# Altium schematic sheet SDCard.SchDoc(SDCard)
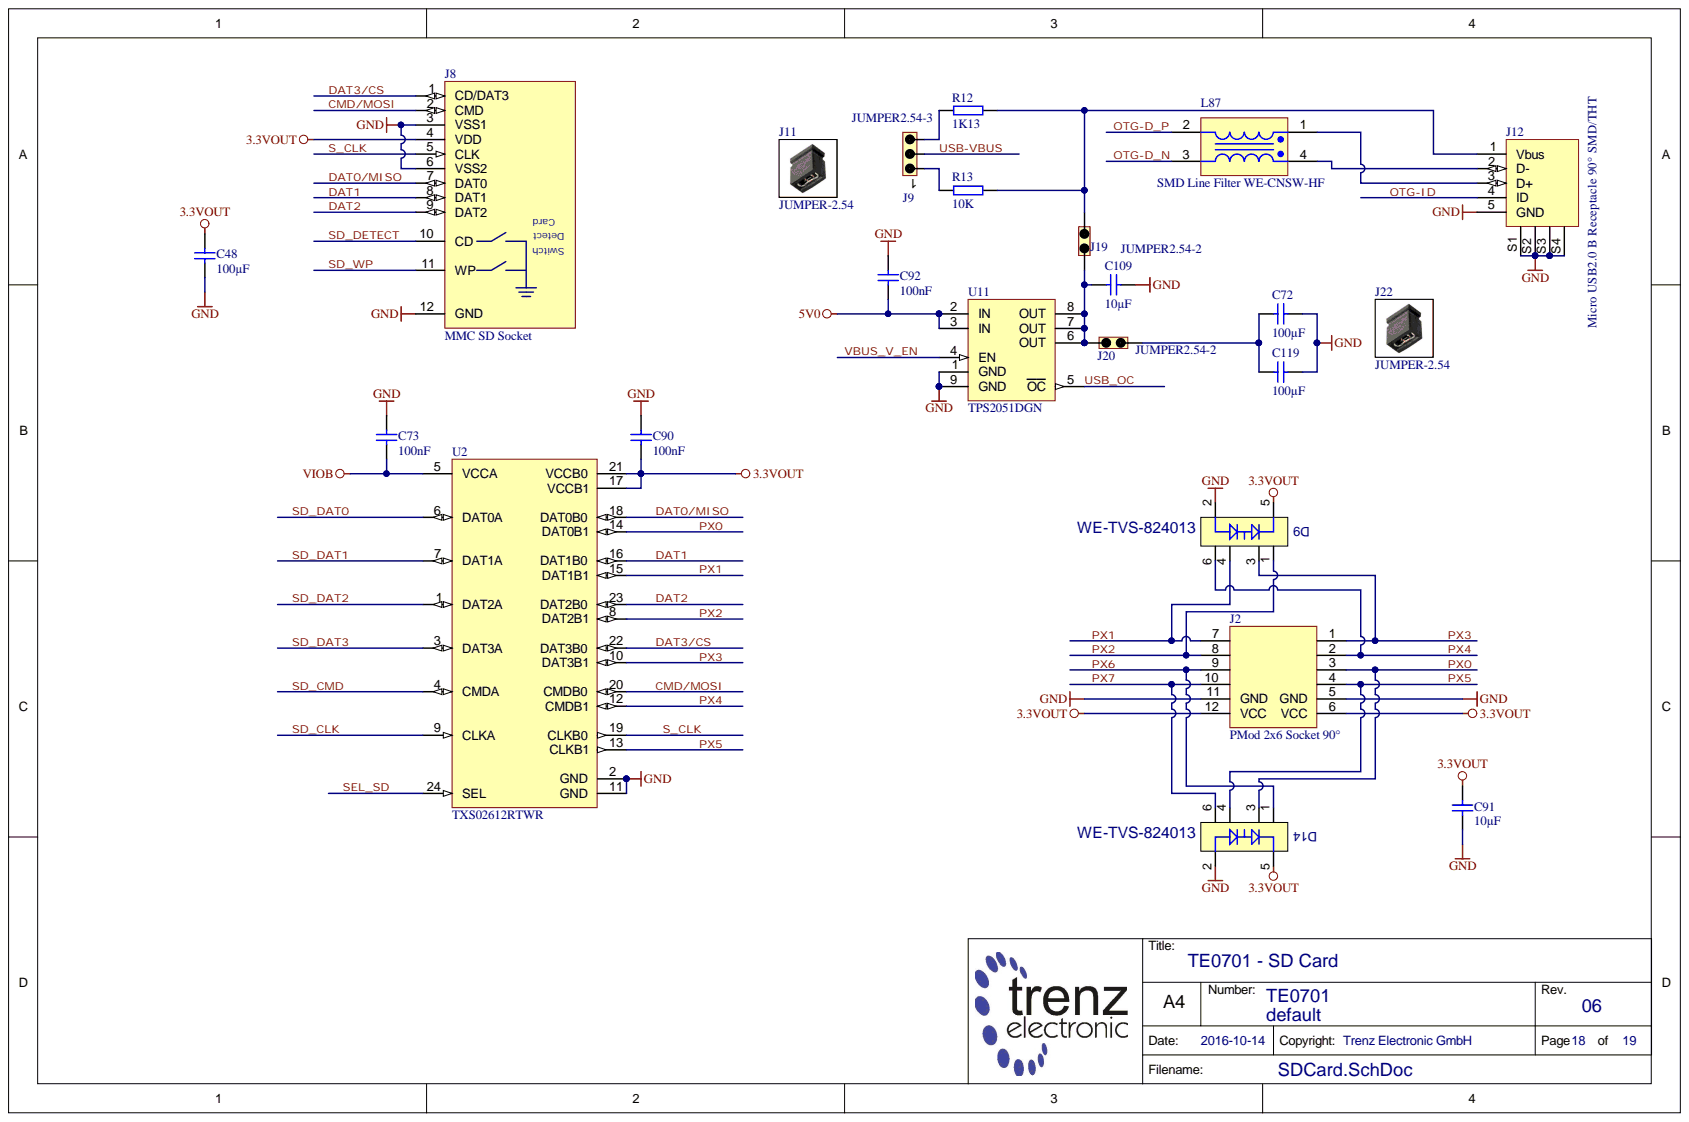
[diagram p0: SDCard.SchDoc]

## Parts
C48  100µF  [CAPC3216X180N]
C72  100µF  [CAPC3216X180N]
C73  100nF  [CAPC1005X55N]
C90  100nF  [CAPC1005X55N]
C91  10µF  [CAPC2012X135N]
C92  100nF  [CAPC1005X55N]
C109  10µF  [CAPC2012X135N]
C119  100µF  [CAPC3216X180N]
D9  WE-TVS-824013  [SOT95P280X145-6N]
D14  WE-TVS-824013  [SOT95P280X145-6N]
J2  PMod 2x6 Socket 90°  [PMod2x6]
J8  MMC SD Socket  Case: MMC SD  [104C-TAA0-R]
J9  JUMPER2.54-3  [JUMPER2_54-3]
J11  JUMPER-2.54  (mechanical)
J12  Micro USB2.0 B Receptacle 90° SMD/THT  [629105150521]
J19  JUMPER2.54-2  [JUMPER2_54-2]
J20  JUMPER2.54-2  [JUMPER2_54-2]
J22  JUMPER-2.54  (mechanical)
L87  SMD Line Filter WE-CNSW-HF  [WE-CNSW-HF]
R12  1K13  [RESC1005X40N]
R13  10K  [RESC1005X40N]
U2  TXS02612RTWR  [QFN50P400X400X80-25N-255]
U11  TPS2051DGN  [SOP65P488X107-9N-TI]

## Nets
3.3VOUT: C48.2 C90.1 C91.1 D9.5 D14.5 J2.6(VCC) J2.12(VCC) J8.4(VDD) U2.17(VCCB1) U2.21(VCCB0)
5V0: C92.1 U11.2(IN) U11.3(IN)
GND: C48.1 C72.1 C73.2 C90.2 C91.2 C92.2 C109.1 C119.2 D9.2 D14.2 J2.5(GND) J2.11(GND) J8.3(VSS1) J8.6(VSS2) J8.12(GND) J12.5(GND) J12.S1 J12.S2 J12.S3 J12.S4 U2.2(GND) U2.11(GND) U11.1(GND) U11.9(GND)
VIOB: C73.1 U2.5(VCCA)
CMD/MOSI: J8.2(CMD) U2.20(CMDB0)
DAT0/MISO: J8.7(DAT0) U2.18(DAT0B0)
DAT1: J8.8(DAT1) U2.16(DAT1B0)
DAT2: J8.9(DAT2) U2.23(DAT2B0)
DAT3/CS: J8.1(CD/DAT3) U2.22(DAT3B0)
OTG-D_N: L87.3
OTG-D_P: L87.2
OTG-ID: J12.4(ID)
PX0: D14.3 J2.3 U2.14(DAT0B1)
PX1: D9.4 J2.7 U2.15(DAT1B1)
PX2: D9.1 J2.8 U2.8(DAT2B1)
PX3: D9.3 J2.1 U2.10(DAT3B1)
PX4: D9.6 J2.2 U2.12(CMDB1)
PX5: D14.4 J2.4 U2.13(CLKB1)
PX6: D14.1 J2.9
PX7: D14.6 J2.10
S_CLK: J8.5(CLK) U2.19(CLKB0)
SD_CLK: U2.9(CLKA)
SD_CMD: U2.4(CMDA)
SD_DAT0: U2.6(DAT0A)
SD_DAT1: U2.7(DAT1A)
SD_DAT2: U2.1(DAT2A)
SD_DAT3: U2.3(DAT3A)
SD_DETECT: J8.10(CD)
SD_WP: J8.11(WP)
SEL_SD: U2.24(SEL)
USB-VBUS: J9.2
USB_OC: U11.5(OC)
VBUS_V_EN: U11.4(EN)
NetC72_2: C72.2 C119.1 J20.2
NetC109_2: C109.2 J19.1 J20.1 U11.6(OUT) U11.7(OUT) U11.8(OUT)
NetJ9_1: J9.1 R13.1
NetJ9_3: J9.3 R12.1
NetJ12_1: J12.1(Vbus) J19.2 R12.2 R13.2
NetJ12_2: J12.2(D-) L87.4
NetJ12_3: J12.3(D+) L87.1

## Library data of the parts
C48 C72 C119 = 23116: KON 100µF 6,3V X5R SMD 20%; Database Table Name: C_3216_1206; Mixed: SMT; Preferred: Yes; Value: 100u; Voltage: 6.3V; AN: 23116; Case: 3216 (1206); Component Kind: Standard; Library Name: TE.DbLib; Technology: X5R; Tolerance: 20%
C73 C90 C92 = 22472: KON 100nF 25V X5R SMD; Database Table Name: C_1005_0402; Mixed: SMT; Preferred: Yes; Value: 100n; AN: 22472; Case: 1005 (0402); Component Kind: Standard; Library Name: TE.DbLib; Technology: X7R; Voltage: 25V
C91 C109 = 24380: KON 10µF 16V X7R SMD 10%; Database Table Name: C_2012_0805; Mixed: SMT; Preferred: Yes; Value: 10u; AN: 24380; Case: 2012 (0805); Component Kind: Standard; Library Name: TE.DbLib; Technology: X7R; Tolerance: 10%; Voltage: 16V
D9 D14 = 23986: TVS Diode Array WE-TVS 824013; H-ART: 824013; Mixed: SMT; Preferred: Yes; AN: 23986; Case: SOT23-6; Component Kind: Standard; Database Table Name: DIODE; Library Name: TE.DbLib; Trenz-Stock: Yes; HelpURL: B:\AD\adlib\datasheet\WE-TVS-824013
J2 = 25215: Database Table Name: CON_IO; Mixed: THT; Trenz-Article-Number: 23185; Trenz-Stock: Yes; AN: 25215; Component Kind: Standard; Description: PMod 2x6 Socket 90°; Library Name: TE.DbLib
J8 = 24818: MCC SD Socket; H-ART: 693063010911; Mixed: SMT; Trenz-Stock: Yes; AN: 24818; Component Kind: Standard; Database Table Name: SOCKET; Library Name: TE.DbLib; HelpURL: B:\AD\adlib\datasheet\104C-TAA0-R
J9 = 22328: Stiftleiste gerade 1-reihig, 3pol.; Database Table Name: CON_HEADER; Mixed: THT; Trenz-Stock: Yes; AN: 22328; Component Kind: Standard; Library Name: TE.DbLib
J11 J22 = 20996: Jumper 2,54mm Raster, Schwarz offen; Database Table Name: CON_MATE; Mixed: MECH; AN: 20996; Library Name: TE.DbLib
J12 = 27235: Database Table Name: CON_IO; Mixed: SMT; AN: 27235; Component Kind: Standard; Description: Micro USB2.0 B Receptacle 90° SMD/THT; H-ART: 629105150521; Library Name: TE.DbLib; HelpURL: B:\AD\adlib\datasheet\10118194-0001LF
J19 J20 = 22330: Stiftleiste gerade 1-reihig, 2pol. (Jumper); Database Table Name: CON_HEADER; Mixed: THT; Trenz-Stock: Yes; AN: 22330; Component Kind: Standard; Library Name: TE.DbLib
L87 = 24514: Stromkompensierter SMD Line Filter HF 320mA 0,24Ohm 50V; Database Table Name: L_SMD; H-ART: 744233670; Mixed: SMT; Preferred: Yes; AN: 24514; Component Kind: Standard; Library Name: TE.DbLib; Technology: 0.24Ohm; Trenz-Stock: Yes; Voltage: 320mA; HelpURL: B:\AD\adlib\datasheet\WE-CNSW HF
R12 = 23160: WID SMD 1K13 1% 0,063W; Database Table Name: R_1005_0402; Mixed: SMT; Preferred: Yes; Value: 1.13K; AN: 23160; Case: 1005 (0402); Component Kind: Standard; Library Name: TE.DbLib; Technology: TK100; Tolerance: 1%
R13 = 22750: WID SMD 10K 1% 0,063W; Database Table Name: R_1005_0402; Mixed: SMT; Preferred: Yes; AN: 22750; Case: 1005 (0402); Component Kind: Standard; Library Name: TE.DbLib; Technology: TK100; Tolerance: 1%; Value: 10K
U2 = 24904: Database Table Name: IF; Mixed: SMT; Trenz-Stock: Yes; AN: 24904; Case: QFN24; Component Kind: Standard; Description: TXS02612RTWR; H-ART: TXS02612RTWR; Library Name: TE.DbLib; HelpURL: B:\AD\adlib\datasheet\TXS02612
U11 = 25167: TPS2051BDGN; Database Table Name: SWITCH; Mixed: SMT; Preferred: Yes; AN: 25167; Component Kind: Standard; H-ART: TPS2051BDGN; Library Name: TE.DbLib; Trenz-Stock: Yes; HelpURL: B:\AD\adlib\datasheet\TPS2051BDGN
